# Altium schematic sheet STM32H7-Board.SchDoc(STM32H7-Board)
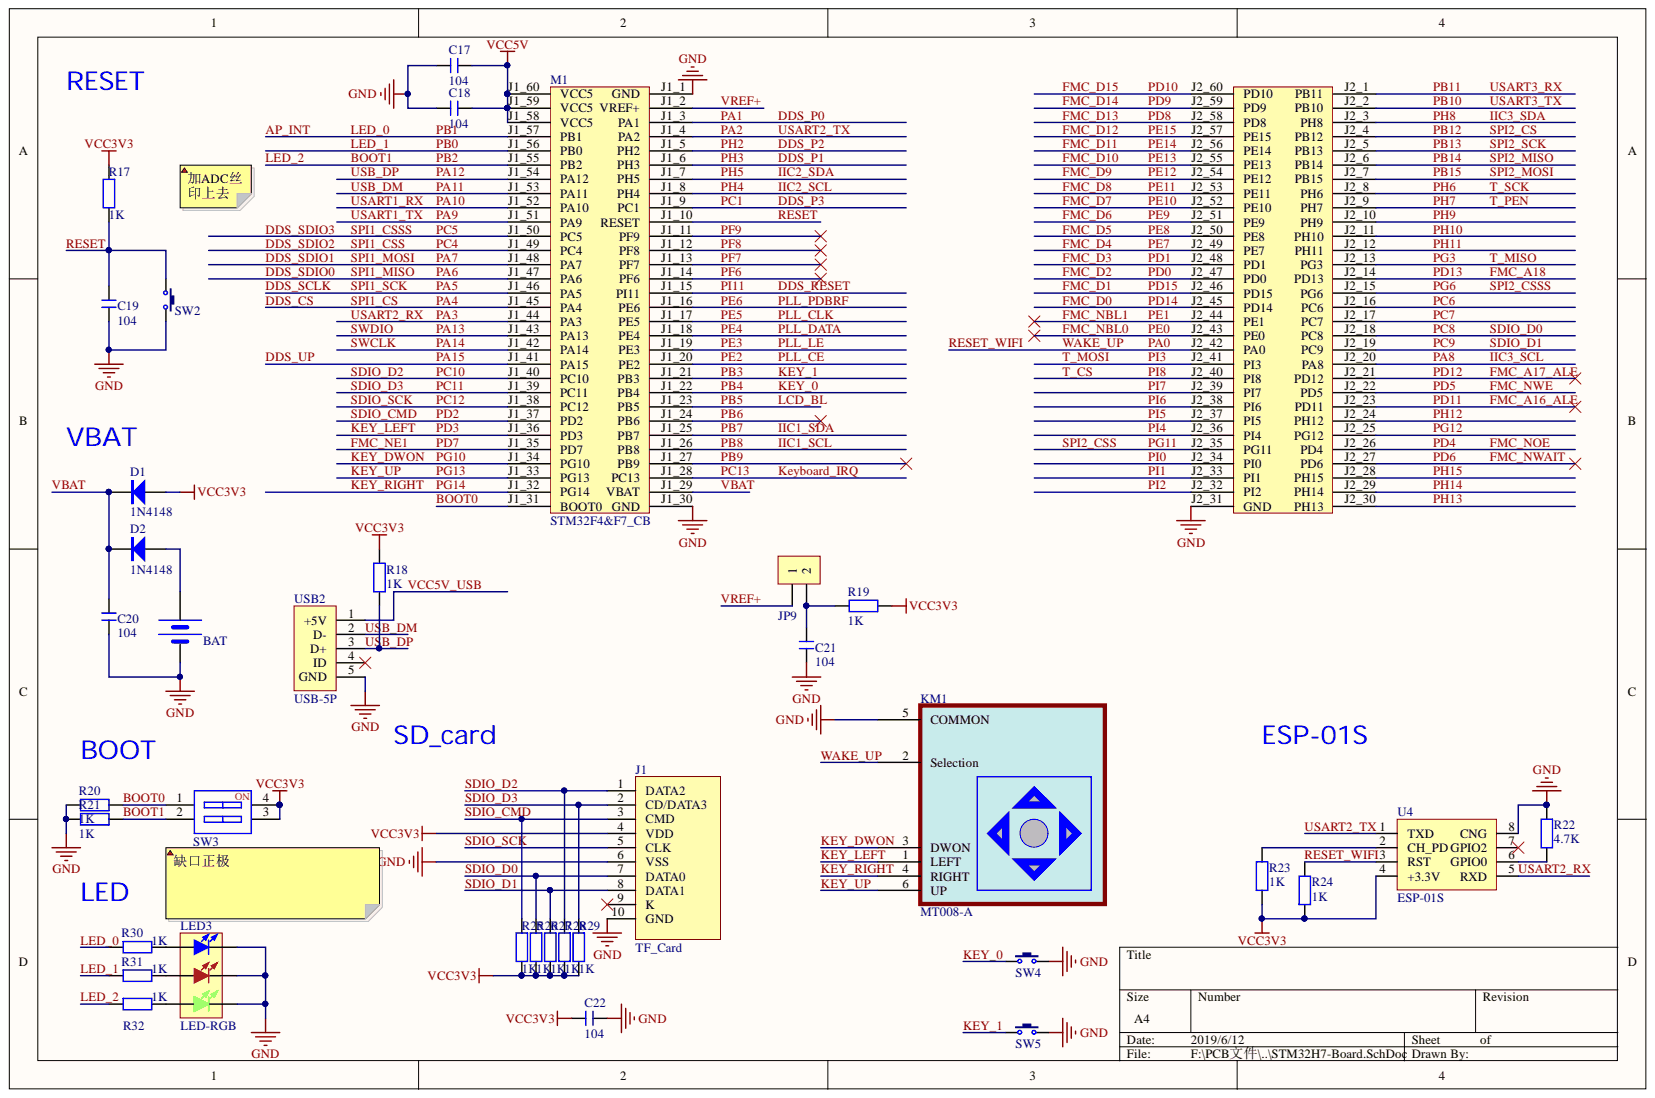
[diagram p0: STM32H7-Board.SchDoc]

## Parts
BAT  BATT  [BATM]  (value not drawn)
C17  104  [C 0603_L]  (value not drawn)
C18  104  [C 0603_L]
C19  104  [C 0603_L]
C20  104  [C 0603_L]
C21  104  [C 0603_L]
C22  104  [C 0603_L]
D1  1N4148  [SOD-323]
D2  1N4148  [MINI_MELF (LL34)]
J1  TF_Card  [Micro SD]
JP9  HDR-1X2  [HDR2.54-LI-2P]  (value not drawn)
KM1  MT008-A  [JOY_MT008-A]
LED3  LED-RGB  [LED 5050]
M1  STM32F4&F7_CB  [STM32F4&F7_CB]
R17  1K  [R 0603_L]
R18  1K  [R 0603_L]
R19  1K  [R 0603_L]
R20  1K  [R 0603_L]
R21  1K  [R 0603_L]
R22  4.7K  [R 0603_L]
R23  1K  [R 0603_L]
R24  1K  [R 0603_L]
R25  1K  [R 0603_L]
R26  1K  [R 0603_L]
R27  1K  [R 0603_L]
R28  1K  [R 0603_L]
R29  1K  [R 0603_L]
R30  1K  [R 0603_L]
R31  1K  [R 0603_L]
R32  1K  [R 0603_L]
SW2  3x6x5  [TSW SMD-3*6*2.5]  (value not drawn)
SW3  CSW-2P  [CSW1.27 SMD-2P]  (value not drawn)
SW4  3x6x5  [SODFL700X110-2N]  (value not drawn)
SW5  3x6x5  [SODFL700X110-2N]  (value not drawn)
U4  ESP-01S  [ESP-01S]
USB2  USB-5P  [USB-MICRO_B]

## Nets
GND: BAT.2 C17.1 C18.1 C19.1 C20.1 C21.1 C22.2 J1.6(VSS) J1.10(GND) KM1.5(COMMON) LED3.2 LED3.4 LED3.6 M1.J1_1 M1.J1_30 M1.J2_31 R20.1 R21.1 R22.2 SW2.2 SW4.2 SW5.2 U4.8(CNG) USB2.5(GND)
VCC3V3: C22.1 D1.1 J1.4(VDD) R17.2 R18.1 R19.2 R23.2 R24.2 R25.1 R26.1 R27.1 R28.1 R29.1 SW3.3 SW3.4 U4.4(+3.3V)
VCC5V: C17.2 C18.2 M1.J1_58(VCC5) M1.J1_59(VCC5) M1.J1_60(VCC5)
AP_INT: M1.J1_57(PB1) R30.2
BOOT0: M1.J1_31(BOOT0) R20.2 SW3.1
BOOT1: M1.J1_55(PB2) R21.2 R32.2 SW3.2
DDS_CS: M1.J1_45(PA4)
DDS_P0: M1.J1_3
DDS_P1: M1.J1_6
DDS_P2: M1.J1_5
DDS_P3: M1.J1_9
DDS_RESET: M1.J1_15
DDS_SCLK: M1.J1_46(PA5)
DDS_SDIO0: M1.J1_47(PA6)
DDS_SDIO1: M1.J1_48(PA7)
DDS_SDIO2: M1.J1_49(PC4)
DDS_SDIO3: M1.J1_50(PC5)
DDS_UP: M1.J1_41(PA15)
FMC_A16_ALE: M1.J2_23(PD11)
FMC_A17_ALE: M1.J2_21(PD12)
FMC_A18: M1.J2_14(PD13)
FMC_D0: M1.J2_45
FMC_D1: M1.J2_46
FMC_D2: M1.J2_47(PD0)
FMC_D3: M1.J2_48
FMC_D4: M1.J2_49
FMC_D5: M1.J2_50(PE8)
FMC_D6: M1.J2_51
FMC_D7: M1.J2_52(PE10)
FMC_D8: M1.J2_53
FMC_D9: M1.J2_54
FMC_D10: M1.J2_55
FMC_D11: M1.J2_56
FMC_D12: M1.J2_57(PE15)
FMC_D13: M1.J2_58
FMC_D14: M1.J2_59
FMC_D15: M1.J2_60
FMC_NBL0: M1.J2_43
FMC_NBL1: M1.J2_44
FMC_NE1: M1.J1_35(PD7)
FMC_NOE: M1.J2_26(PD4)
FMC_NWAIT: M1.J2_27(PD6)
FMC_NWE: M1.J2_22(PD5)
IIC1_SCL: M1.J1_26
IIC1_SDA: M1.J1_25
IIC2_SCL: M1.J1_8
IIC2_SDA: M1.J1_7
IIC3_SCL: M1.J2_20(PA8)
IIC3_SDA: M1.J2_3(PH8)
KEY_0: M1.J1_22 SW4.1
KEY_1: M1.J1_21 SW5.1
KEY_DWON: KM1.3(DWON) M1.J1_34(PG10)
KEY_LEFT: KM1.1(LEFT) M1.J1_36(PD3)
KEY_RIGHT: KM1.4(RIGHT) M1.J1_32(PG14)
KEY_UP: KM1.6(UP) M1.J1_33(PG13)
Keyboard_IRQ: M1.J1_28
LCD_BL: M1.J1_23
LED_1: M1.J1_56(PB0) R31.2
PA0: KM1.2(Selection) M1.J2_42(PA0) R24.1 U4.3(RST)
PA2: M1.J1_4 U4.1(TXD)
PA3: M1.J1_44(PA3) U4.5(RXD)
PA9: M1.J1_51(PA9)
PA10: M1.J1_52(PA10)
PA11: M1.J1_53(PA11) USB2.2(D-)
PA12: M1.J1_54(PA12) R18.2 USB2.3(D+)
PA13: M1.J1_43(PA13)
PA14: M1.J1_42(PA14)
PB6: M1.J1_24
PB9: M1.J1_27
PB10: M1.J2_2(PB10)
PB11: M1.J2_1(PB11)
PB12: M1.J2_4(PB12)
PB13: M1.J2_5(PB13)
PB14: M1.J2_6(PB14)
PB15: M1.J2_7(PB15)
PC6: M1.J2_16(PC6)
PC7: M1.J2_17(PC7)
PC8: J1.7(DATA0) M1.J2_18(PC8) R26.2
PC9: J1.8(DATA1) M1.J2_19(PC9) R27.2
PC10: J1.1(DATA2) M1.J1_40(PC10) R28.2
PC11: J1.2(CD/DATA3) M1.J1_39(PC11) R29.2
PC12: J1.5(CLK) M1.J1_38(PC12)
PD2: J1.3(CMD) M1.J1_37(PD2) R25.2
PE2: M1.J1_20
PE3: M1.J1_19
PE4: M1.J1_18
PE5: M1.J1_17
PE6: M1.J1_16
PF6: M1.J1_14
PF7: M1.J1_13
PF8: M1.J1_12
PF9: M1.J1_11
PG3: M1.J2_13(PG3)
PG6: M1.J2_15(PG6)
PG11: M1.J2_35
PG12: M1.J2_25(PG12)
PH6: M1.J2_8(PH6)
PH7: M1.J2_9(PH7)
PH9: M1.J2_10(PH9)
PH10: M1.J2_11(PH10)
PH11: M1.J2_12(PH11)
PH12: M1.J2_24(PH12)
PH13: M1.J2_30(PH13)
PH14: M1.J2_29(PH14)
PH15: M1.J2_28(PH15)
PI0: M1.J2_34
PI1: M1.J2_33
PI2: M1.J2_32
PI3: M1.J2_41
PI4: M1.J2_36
PI5: M1.J2_37
PI6: M1.J2_38
PI7: M1.J2_39
PI8: M1.J2_40
RESET: C19.2 M1.J1_10 R17.1 SW2.1
VBAT: C20.2 D1.2 D2.2 M1.J1_29
VCC5V_USB: USB2.1(+5V)
VREF+: JP9.1 M1.J1_2
NetBAT_1: BAT.1 D2.1
NetC21_2: C21.2 JP9.2 R19.1
NetLED3_1: LED3.1 R30.1
NetLED3_3: LED3.3 R31.1
NetLED3_5: LED3.5 R32.1
NetR22_1: R22.1 U4.6(GPIO0)
NetR23_1: R23.1 U4.2(CH_PD)

Not connected: J1 9(K); U4 7(GPIO2); USB2 4(ID)

## Library data of the parts
BAT = BAT: Component Kind: Standard; Library Name: MERCURY.SCHLIB
C17-C22 = C: 无极性贴片电容; 版权归属: 源创客; 学习资源: www.ooocke.com; Component Kind: Standard; BOM名称: 无极性贴片电容
  C17-C21: Library Name: 3.1   - 电阻-电容-电感.SchLib
  C22: Library Name: 电阻-电容-电感.SchLib
D1 D2 = 1N4148: 高速开关二极管; 版权归属: 源创客; 学习资源: www.ooocke.com; Library Name: 8.1   - 二极管-整流桥.SchLib; Component Kind: Standard; BOM名称: 高速开关二极管
J1 = TF_Card: TF卡座; 版权归属: 源创客; 学习资源: www.ooocke.com; Library Name: 4.1   - 接口类.SchLib; Component Kind: Standard; BOM名称: TF卡座
JP9 = Header 2: 2P接插件; 版权归属: 源创客; 学习资源: www.ooocke.com; Library Name: 4.5   - 接插件-脚距2.54.SchLib; Component Kind: Standard; BOM名称: 2P接插件
KM1 = MT-008A: Library Name: 13.1 - 模块.SchLib; Component Kind: Standard
LED3 = LED-RGB: 三基色LED; 版权归属: 源创客; 学习资源: www.ooocke.com; Library Name: LED.SchLib; Component Kind: Standard; BOM名称: 三基色LED
M1 = STM32F4&F7_CB: F429/746 Core Board; Component Kind: Standard; Library Name: MERCURY.SCHLIB
R17-R32 = R: 贴片电阻; 版权归属: 源创客; 学习资源: www.ooocke.com; Component Kind: Standard; BOM名称: 贴片电阻
  R17 R25-R29: Library Name: 电阻-电容-电感.SchLib
  R18-R24 R30-R32: Library Name: 3.1   - 电阻-电容-电感.SchLib
SW2 SW4 SW5 = TSW 3x6: 3x6轻触开关; 版权归属: 源创客; 学习资源: www.ooocke.com; Library Name: 5.1   - 按键-开关.SchLib; Component Kind: Standard; BOM名称: 3x6轻触开关
SW3 = CSW-2P: 2路编码开关; 版权归属: 源创客; 学习资源: www.ooocke.com; Library Name: 5.1   - 按键-开关.SchLib; Component Kind: Standard; BOM名称: 2路编码开关
USB2 = USB-5P: 微型USB母座; 版权归属: 源创客; 学习资源: www.ooocke.com; Library Name: 4.1   - 接口类.SchLib; Component Kind: Standard; BOM名称: 微型USB母座
U4 = ESP-01S: Library Name: 13.1 - 模块.SchLib; Description: ESP-01S; Component Kind: Standard
